# Altium schematic sheet Схема_принципиальная_общая.SchDoc
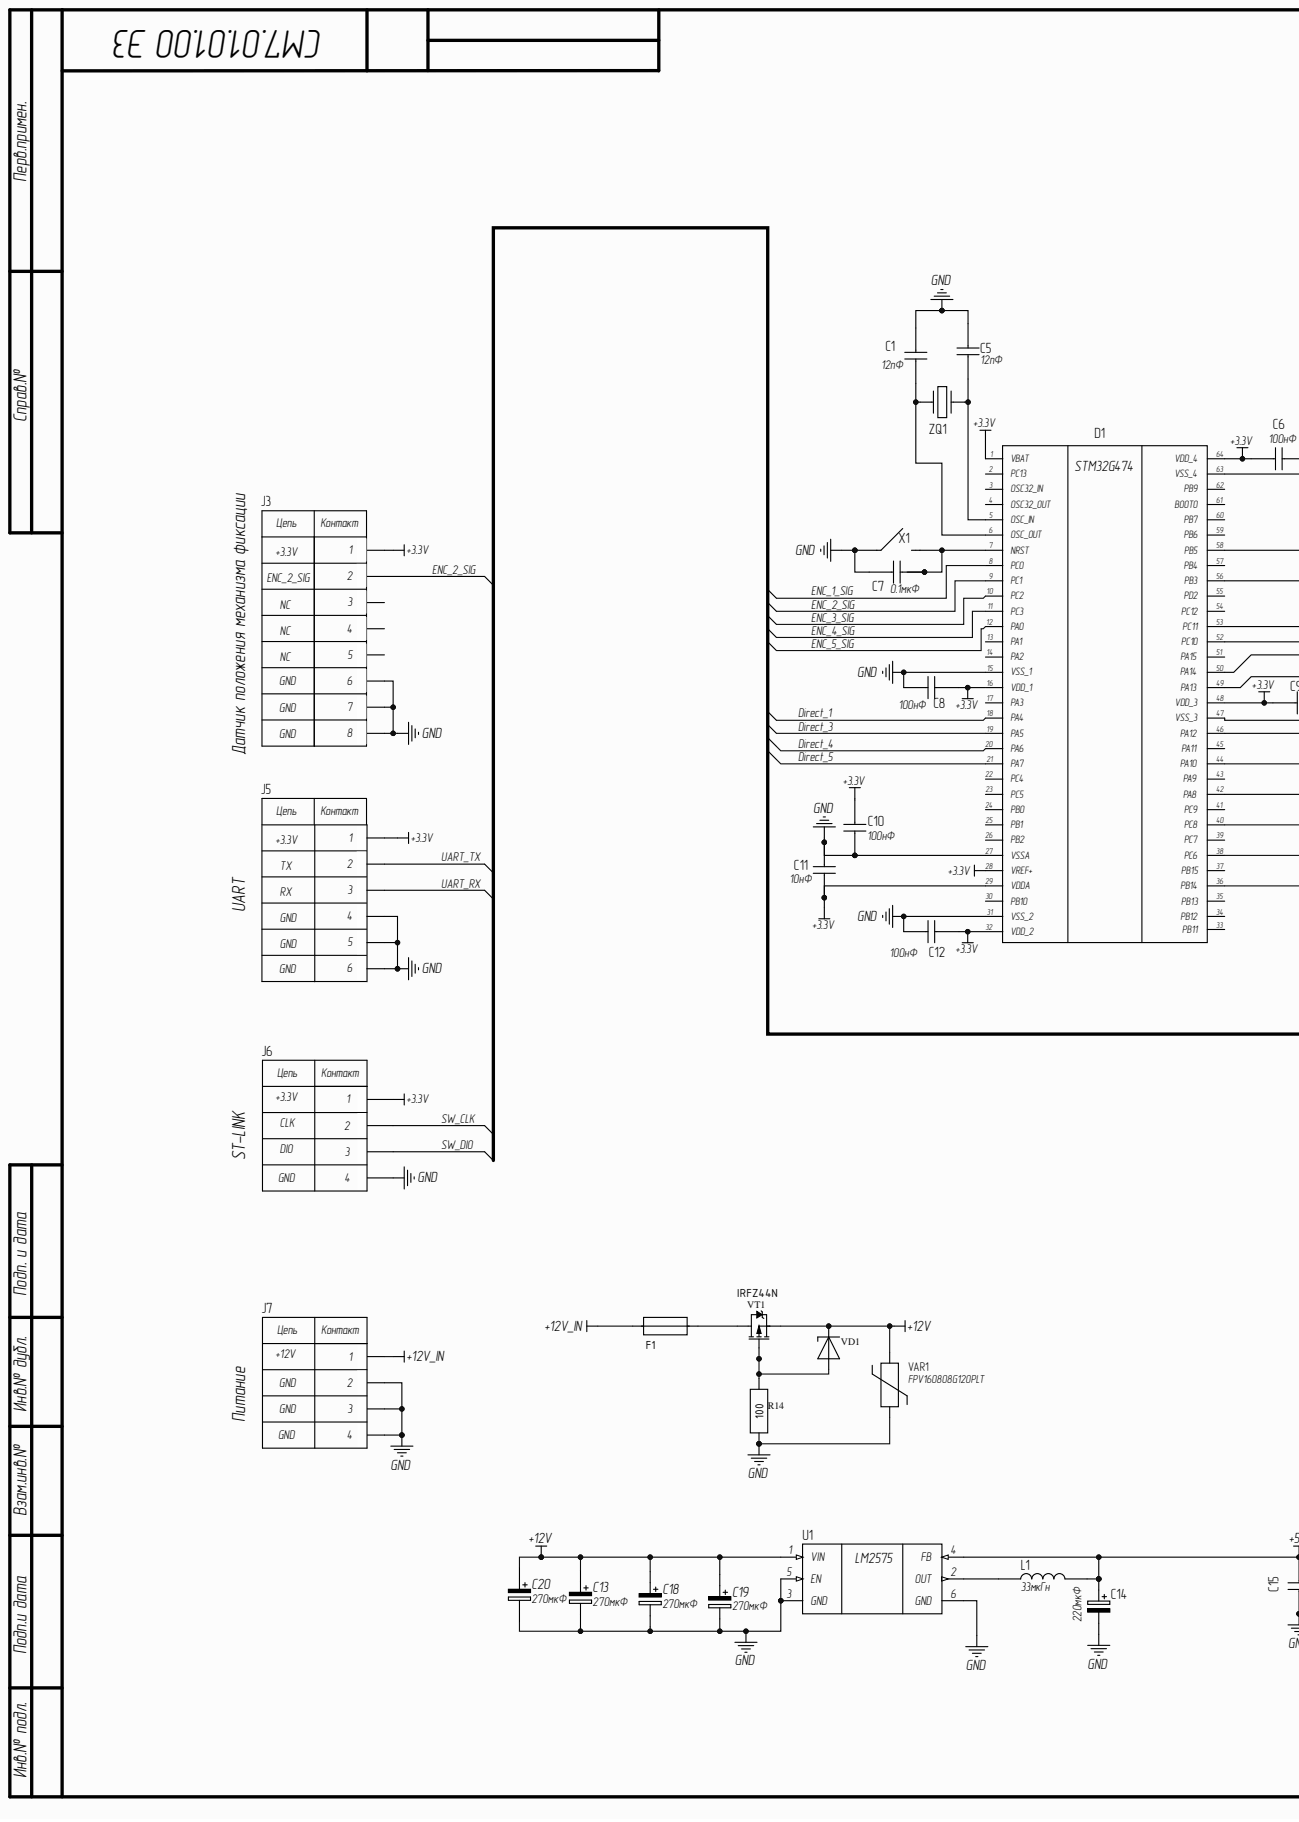
[diagram p0: Схема_принципиальная_общая.SchDoc, left]
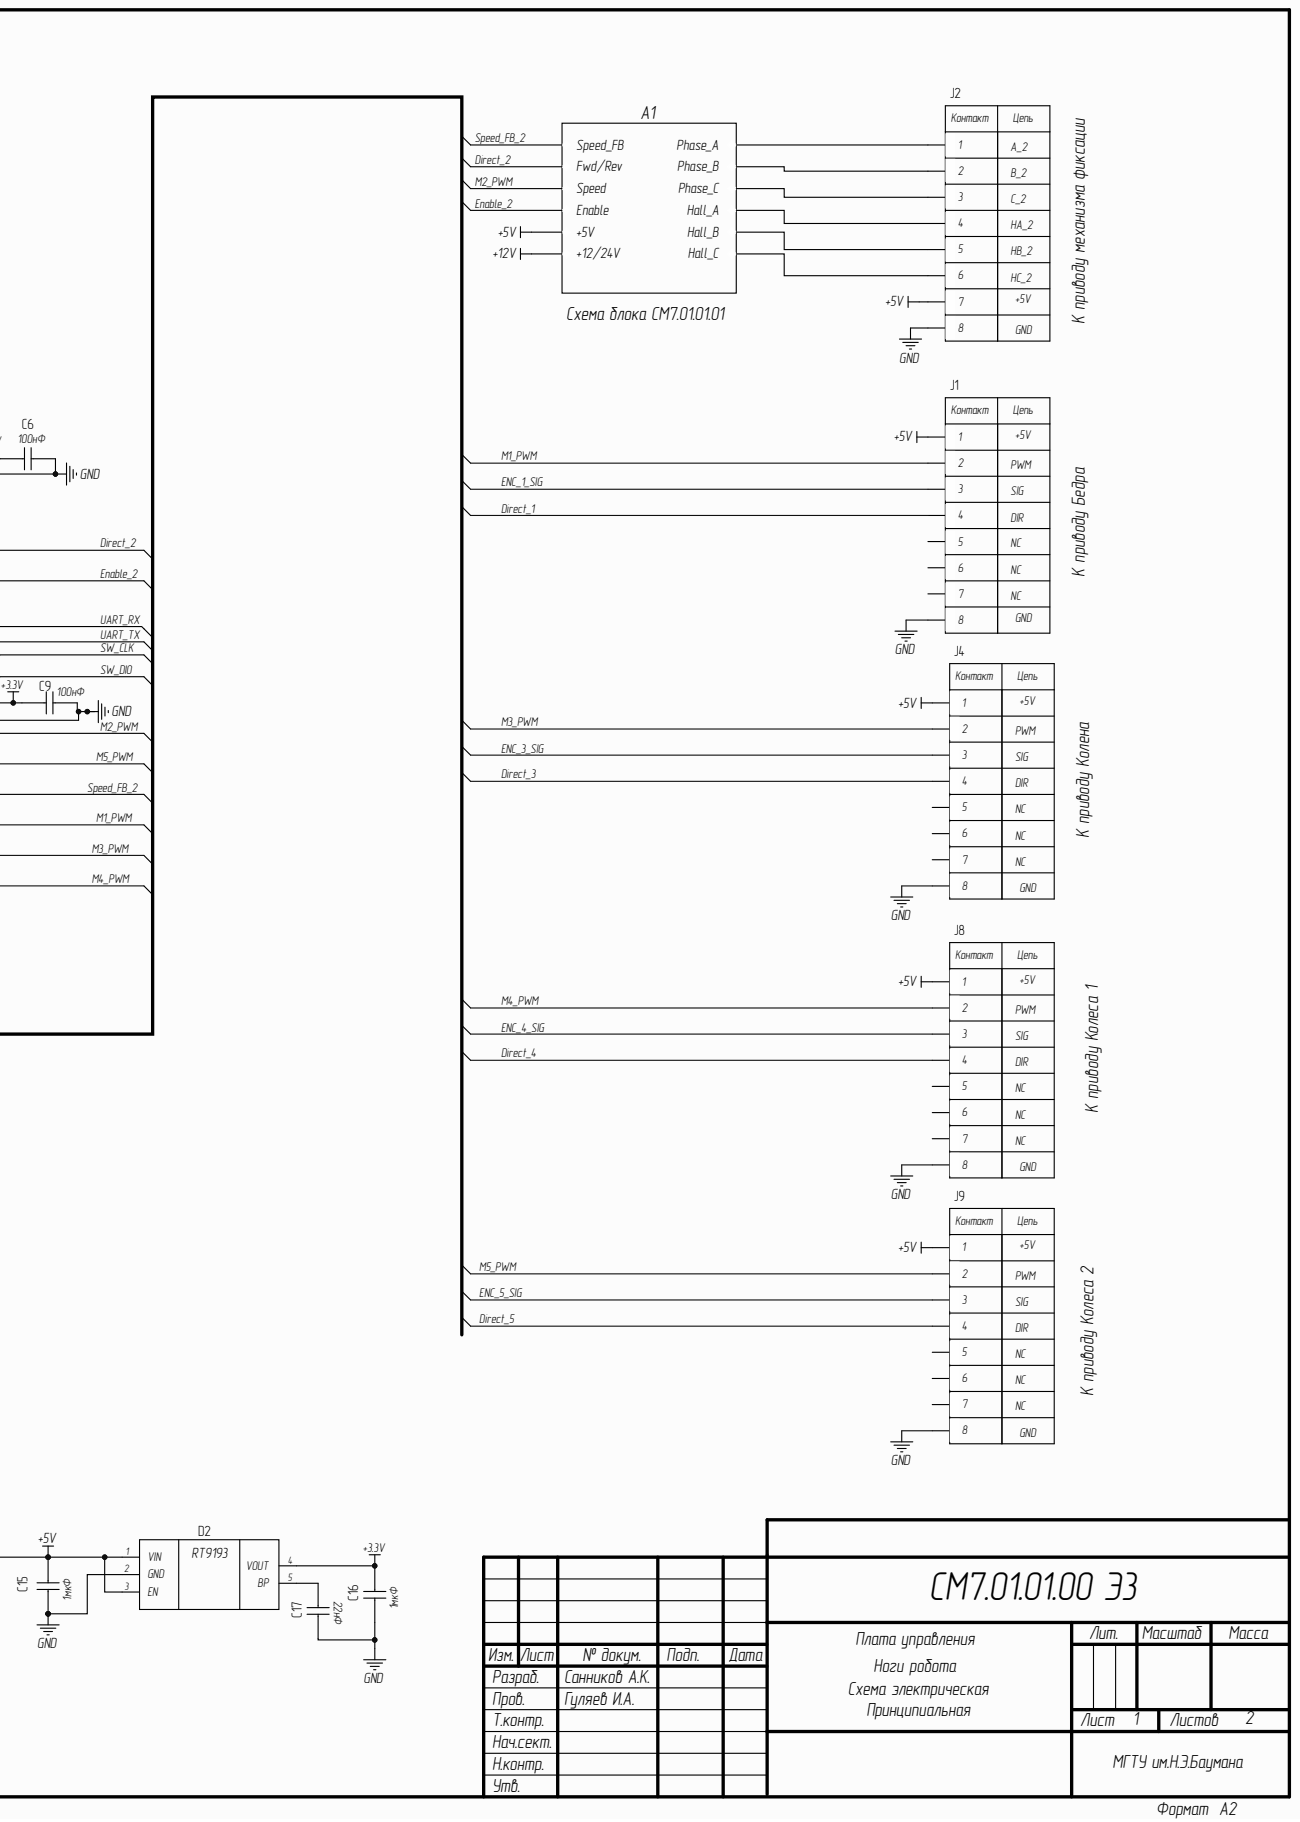
[diagram p1: Схема_принципиальная_общая.SchDoc, right]

## Parts
C1  12пФ  [CAPC1608X87N]
C5  12пФ  [CAPC1608X87N]
C6  100нФ  [CAPC1608X87N]
C7  0.1мкФ  [CAPC1608X87N]
C8  100нФ  [CAPC1608X87N]
C9  100нФ  [CAPC1608X87N]
C10  100нФ  [CAPC1608X87N]
C11  10нФ  [CAPC1608X87N]
C12  100нФ  [CAPC1608X87N]
C13  270мкФ  [16SVF270M]
C14  220мкФ  [CAPPM3216X180N]
C15  1мкФ  [CAPC1608X87N]
C16  1мкФ  [CAPC1608X87N]
C17  22нФ  [CAPC1608X87N]
C18  270мкФ  [16SVF270M]
C19  270мкФ  [16SVF270M]
C20  270мкФ  [16SVF270M]
D1  (no value)  [QFP50P1200X1200X160-64N]
D2  (no value)  [RT9193]
F1  Fuze_LB250LVF  [Fuze_LB250LVF]  (value not drawn)
J1  430450800  [MOLEX_430450800]  (value not drawn)
J2  430450800  [MOLEX_430450800]  (value not drawn)
J3  BM06B-SRSS-TB_LF__SN_  [BM06BSRSSTBLFSN]  (value not drawn)
J4  430450800  [MOLEX_430450800]  (value not drawn)
J5  BM04B-SRSS-TB_LFSN_  [BM04BSRSSTBLFSN]  (value not drawn)
J6  4-pin header  [4-pin header]  (value not drawn)
J7  XT30PW-M  [XT30PWM]  (value not drawn)
J8  430450800  [MOLEX_430450800]  (value not drawn)
J9  430450800  [MOLEX_430450800]  (value not drawn)
L1  33мкГн  [INDPM7373X450N]
R14  value 100  [RES: 0805]  (hidden comment: Resistor 0805 100 Ohm)
U1  LM2575HVSX-5.0_NOPB  [VREG_LM2575HVSX-5.0_NOPB]  (value not drawn)
VAR1  FPV160808G120PLT  [Varistor_20K391]
VD1  Zener diode, 5.1V, 500mW, 0.9V, 17Ohm, SOD-123, -55...+150°C  [DIODE: SMB]  (value not drawn)
VT1  MOSFET P-channel transistor, 20V, 2.6A, 2.9nC, 2.5W, 135mOhm, 220pF, SOT-23, -55...+150°C  [DPAK]  (value not drawn)
X1  1437566-3  [14375663]  (value not drawn)
ZQ1  NX5032GA-12.000M-LN-CD-1  [INDC5032X150N]  (value not drawn)

## Nets
+3.3V: C6.2 C8.2 C9.2 C10.2 C11.1 C12.2 C16.2 D1.1(VBAT) D1.28(VREF+) D1.29(VDDA) D1.64(VDD_4) D2.4(VOUT) J3.1 J5.1 J6.1
+5V: C14.1 C15.2 D2.1(VIN) D2.3(EN) J1.1 J2.7 J4.1 J8.1 J9.1 L1.2 U1.4(FB)
+12V: C13.1 C18.1 C19.1 C20.1 U1.1(VIN) VAR1.2 VD1.2 VT1.2
+12V_IN: F1.1 J7.1
GND: C1.2 C5.2 C6.1 C7.2 C8.1 C9.1 C10.1 C11.2 C12.1 C13.2 C14.2 C15.1 C16.1 C17.1 C18.2 C19.2 C20.2 D1.15(VSS_1) D1.27(VSSA) D1.31(VSS_2) D1.47(VSS_3) D1.63(VSS_4) D2.2(GND) J1.8 J2.8 J3.6 J3.7 J3.8 J4.8 J5.4 J5.MP1 J5.MP2 J6.4 J7.2 J7.3 J7.4 J8.8 J9.8 R14.1 U1.3(GND) U1.5(EN) U1.6(GND) VAR1.1 X1.1
Direct_1: D1.18(PA4) J1.4
Direct_2: D1.58(PB5)
Direct_3: D1.19(PA5) J4.4
Direct_4: D1.20(PA6) J8.4
Direct_5: D1.21(PA7) J9.4
Enable_2: D1.56(PB3)
ENC_1_SIG: D1.8(PC0) J1.3
ENC_2_SIG: D1.9(PC1) J3.2
ENC_3_SIG: D1.10(PC2) J4.3
ENC_4_SIG: D1.11(PC3) J8.3
ENC_5_SIG: D1.12(PA0) J9.3
M1_PWM: D1.40(PC8) J1.2
M2_PWM: D1.46(PA12)
M3_PWM: D1.38(PC6) J4.2
M4_PWM: D1.36(PB14) J8.2
M5_PWM: D1.44(PA10) J9.2
Speed_FB_2: D1.42(PA8)
SW_CLK: D1.50(PA14) J6.2
SW_DIO: D1.49(PA13) J6.3
UART_RX: D1.53(PC11) J5.3
UART_TX: D1.52(PC10) J5.2
NetC1_1: C1.1 D1.6(OSC_OUT) ZQ1.1
NetC5_1: C5.1 D1.5(OSC_IN) ZQ1.2
NetC7_1: C7.1 D1.7(NRST) X1.2
NetC17_2: C17.2 D2.5(BP)
NetD1_2: D1.2(PC13)
NetD1_3: D1.3(OSC32_IN)
NetD1_4: D1.4(OSC32_OUT)
NetD1_13: D1.13(PA1)
NetD1_14: D1.14(PA2)
NetD1_16: D1.16(VDD_1)
NetD1_17: D1.17(PA3)
NetD1_22: D1.22(PC4)
NetD1_23: D1.23(PC5)
NetD1_24: D1.24(PB0)
NetD1_25: D1.25(PB1)
NetD1_26: D1.26(PB2)
NetD1_30: D1.30(PB10)
NetD1_32: D1.32(VDD_2)
NetD1_33: D1.33(PB11)
NetD1_34: D1.34(PB12)
NetD1_35: D1.35(PB13)
NetD1_37: D1.37(PB15)
NetD1_39: D1.39(PC7)
NetD1_41: D1.41(PC9)
NetD1_43: D1.43(PA9)
NetD1_45: D1.45(PA11)
NetD1_48: D1.48(VDD_3)
NetD1_51: D1.51(PA15)
NetD1_54: D1.54(PC12)
NetD1_55: D1.55(PD2)
NetD1_57: D1.57(PB4)
NetD1_59: D1.59(PB6)
NetD1_60: D1.60(PB7)
NetD1_61: D1.61(BOOT0)
NetD1_62: D1.62(PB9)
NetF1_2: F1.2 VT1.3
NetJ1_5: J1.5
NetJ1_6: J1.6
NetJ1_7: J1.7
NetJ2_1: J2.1
NetJ2_2: J2.2
NetJ2_3: J2.3
NetJ2_4: J2.4
NetJ2_5: J2.5
NetJ2_6: J2.6
NetJ3_3: J3.3
NetJ3_4: J3.4
NetJ3_5: J3.5
NetJ4_5: J4.5
NetJ4_6: J4.6
NetJ4_7: J4.7
NetJ8_5: J8.5
NetJ8_6: J8.6
NetJ8_7: J8.7
NetJ9_5: J9.5
NetJ9_6: J9.6
NetJ9_7: J9.7
NetL1_1: L1.1 U1.2(OUT)
NetR14_2: R14.2 VD1.1 VT1.1

## Library data of the parts
C1 C5-C12 C15-C17 = C0603: C 0603; Manufacturer_Name: KEMET; Manufacturer_Part_Number: C0603C222K4REC7411; Geometry.Height: 0.87mm; Component Kind: Standard; Datasheet Link: https://www.kemet.com/specsheet/C0603C222K4REC7411; Library Name: BLDC_Library.IntLib
C13 C18-C20 = 25SVPF100M: Capacitor Polarised; Manufacturer_Name: Panasonic; Arrow Part Number: 25SVPF100M; Arrow Price/Stock: https://www.arrow.com/en/products/25svpf100m/panasonic?region=nac; Component Kind: Standard; Datasheet Link: http://industrial.panasonic.com/cdbs/www-data/pdf/AAB8000/AAB8000C177.pdf; Height: 7mm; Manufacturer_Part_Number: 25SVPF100M; Mouser Part Number: 667-25SVPF100M; Mouser Price/Stock: https://www.mouser.co.uk/ProductDetail/Panasonic/25SVPF100M?qs=OE1iw1LrrPHrTLW3S9d9vQ%3D%3D
C14 = TAJA104K050R: Capacitor tantalum TAJ 1206 100nF 50V AVX 100nF SMD Solid MnO2 Tantalum Electrolytic Capacitor, 50 V dc +/-10%, TAJ Series; Manufacturer_Name: Kyocera AVX; Geometry.Height: 1.8mm; Component Kind: Standard; Datasheet Link: http://www.farnell.com/datasheets/1680476.pdf; Library Name: BLDC_Library.IntLib; Manufacturer_Part_Number: TAJA104K050R; Mouser Part Number: N/A; Mouser Price/Stock: http://www.mouser.com/Search/ProductDetail.aspx?qs=TDs2sH6HKGut6%252bATQXwpTg%3d%3d
D1 = STM32G474RBT6: LQFP is a 64 pins, 10 x 10 mm low-profile quad flat package.; Manufacturer_Name: STMicroelectronics; Geometry.Height: 1.6mm; Arrow Part Number: STM32G474RBT6; Arrow Price/Stock: https://www.arrow.com/en/products/stm32g474rbt6/stmicroelectronics?region=nac; Component Kind: Standard; Datasheet Link: https://www.mouser.com/datasheet/2/389/stm32g474cb-1600828.pdf; Library Name: BLDC_Library.IntLib; Manufacturer_Part_Number: STM32G474RBT6; Mouser Part Number: 511-STM32G474RBT6; Mouser Price/Stock: https://www.mouser.co.uk/ProductDetail/STMicroelectronics/STM32G474RBT6?qs=uwxL4vQweFP0uAZUZXLBhQ%3D%3D
D2 = RT9193: Component Kind: Standard; Library Name: BLDC_Library.IntLib
F1 = Fuze_LB250LVF: https://www.chipdip.ru/product/lb250lvf-wayon-8030578313; Component Kind: Standard; Library Name: Smart_Boiler_Library.IntLib
J3 = BM06B-SRSS-TB_LF__SN_: Wire To Board / Wire To Wire Connector RoHS; Manufacturer_Name: JST (JAPAN SOLDERLESS TERMINALS); Manufacturer_Part_Number: BM06B-SRSS-TB(LF)(SN); Geometry.Height: 4.25mm; Arrow Part Number: BM06B-SRSS-TB(LF)(SN); Arrow Price/Stock: https://www.arrow.com/en/products/bm06b-srss-tb-lf-sn/jst-manufacturing?region=europe; Component Kind: Standard; Datasheet Link: http://www.jst-mfg.com/product/pdf/eng/eSR.pdf; Library Name: BLDC_Library.IntLib; Mouser Part Number: N/A; Mouser Price/Stock: https://www.mouser.co.uk/ProductDetail/JST-Commercial/BM06B-SRSS-TBLFSN?qs=IKkN%2F947nfAcbKIXx%2F1kIg%3D%3D
J5 = BM04B-SRSS-TB_LFSN_: JST SH, 4 Way, 1 Row, Straight PCB Header; Manufacturer_Name: JST (JAPAN SOLDERLESS TERMINALS); Manufacturer_Part_Number: BM04B-SRSS-TB(LFSN); Geometry.Height: 4.25mm; Arrow Part Number: BM04B-SRSS-TB(LFSN); Arrow Price/Stock: https://www.arrow.com/en/products/bm04b-srss-tb-lf-sn/jst-manufacturing?region=europe; Component Kind: Standard; Datasheet Link: http://uk.rs-online.com/web/p/products/5151541P; Library Name: BLDC_Library.IntLib
J7 = XT30PW-M: 30A Low Temperature Rise Fire Retardant Plug; Manufacturer_Name: Amass; Geometry.Height: 5mm; Component Kind: Standard; Datasheet Link: https://www.tme.eu/sl/Document/ce4077e36b79046da520ca73227e15de/XT30PW%20SPEC.pdf; Library Name: BLDC_Library.IntLib; Manufacturer_Part_Number: XT30PW-M
L1 = B82472G6332M000: SMD / SMT Inductors (Coils), L=3.3uH, 7.3mm x 7.3mm; Manufacturer_Name: TDK; Geometry.Height: 4.5mm; Component Kind: Standard; Datasheet Link: https://product.tdk.com/system/files/dam/doc/product/inductor/inductor/smd/data_sheet/30/db/ind_2008/b82472g6.pdf; Library Name: BLDC_Library.IntLib; Manufacturer_Part_Number: B82472G6332M000; Mouser Part Number: 871-B82472G6332M000; Mouser Price/Stock: https://www.mouser.co.uk/ProductDetail/EPCOS-TDK/B82472G6332M000?qs=x3Z3TaiycdvLmjm0xB%2FIcA%3D%3D
R14 = Resistor 0805 100 Ohm: Manufacturer: Yageo; ValueManufacturerPartNumber: Resistor film, 100, ±5%, 0805, 0.125W, -55...+125°C; Power: 0.125W; Tolerance: ±5%; TypeResistor: Resistor film; Component Kind: Standard; HelpURL: https://www.digikey.com/product-detail/en/yageo/RC0805JR-07100RL/311-100ARCT-ND/731185; Package: 0805; Temperature: -55...+125°C; Library Name: Smart_Boiler_Library.IntLib
U1 = LM2575HVSX-5.0_NOPB: Buck Switching Regulator IC Positive Fixed 5V 1 Output 1A TO-263-6, D2PAK (5 Leads + Tab), TO-263BA; MF: Microchip; MP: LM2575-5.0WU; Check_prices: https://www.snapeda.com/parts/LM2575-5.0WU/Microchip/view-part/?ref=eda; Component Kind: Standard; Library Name: BLDC_Library.IntLib; Package: TO-263-5 Microchip; SnapEDA_Link: https://www.snapeda.com/parts/LM2575-5.0WU/Microchip/view-part/?ref=snap
VAR1 = Vristor 20K391: Component Kind: Standard; Library Name: Smart_Boiler_Library.IntLib
VD1 = Zener_single: Manufacturer: Diodes Incorporated; PartNumber: MMSZ5231B-7-F; Component Kind: Standard; Current: 500mW; HelpURL: https://www.digikey.com/product-detail/en/diodes-incorporated/MMSZ5231B-7-F/MMSZ5231B-FDICT-ND/755506; Library Name: BLDC_Library_2.IntLib; Package: SOD-123; RecoveryTime: 17Ohm; Temperature: -55...+150°C; TypeDiode: Zener diode; ValueManufacturerPartNumber: Zener diode, 5.1V, 500mW, 0.9V, 17Ohm, SOD-123, -55...+150°C; Voltage: 5.1V; VoltageDrop: 0.9V
VT1 = IRFZ44N: Manufacturer: Infineon Technologies; Component Kind: Standard; CurrentDS: 2.6A; GateCharge: 2.9nC; HelpURL: https://www.digikey.com/product-detail/en/infineon-technologies/IRLML2246TRPBF/IRLML2246TRPBFCT-ND/2639576; InputCapacitor: 220pF; Library Name: BLDC_Library_2.IntLib; MaxPower: 2.5W; Package: SOT-23; PartNumber: IRFZ44N; RDS: 135mOhm; Temperature: -55...+150°C; TypeTransistor: MOSFET P-channel transistor; ValueManufacturerPartNumber: MOSFET P-channel transistor, 20V, 2.6A, 2.9nC, 2.5W, 135mOhm, 220pF, SOT-23, -55...+150°C; VoltageDS: 20V
X1 = 1437566-3: 1437566-3 - PUSHBUTTON SWITCH, SPST, 0.05A, 24V; Manufacturer_Name: TE Connectivity; Geometry.Height: 4.57mm; Arrow Part Number: 1437566-3; Arrow Price/Stock: https://www.arrow.com/en/products/1437566-3/te-connectivity?region=europe; Component Kind: Standard; Datasheet Link: https://www.te.com/commerce/DocumentDelivery/DDEController?Action=showdoc&DocId=Customer+Drawing%7F1437566-3%7FC2%7Fpdf%7FEnglish%7FENG_CD_1437566-3_C2_1437566_3_1_c.dwg.pdf%7F1437566-3; Library Name: BLDC_Library.IntLib; Manufacturer_Part_Number: 1437566-3; Mouser Part Number: N/A; Mouser Price/Stock: https://www.mouser.co.uk/ProductDetail/TE-Connectivity-Alcoswitch/1437566-3?qs=xoXDFGdkQ2nqx7TKB39mxQ%3D%3D
ZQ1 = NX5032GA-8.000M: Crystals CRYSTAL 8MHZ 8PF SMD; Manufacturer_Name: NDK; Geometry.Height: 1.5mm; Component Kind: Standard; Datasheet Link: https://componentsearchengine.com/Datasheets/1/NX5032GA-12.000M-LN-CD-1.pdf; Library Name: BLDC_Library.IntLib; Manufacturer_Part_Number: NX5032GA-12.000M-LN-CD-1; Mouser Part Number: 344-NX5032GA12MLNCD1; Mouser Price/Stock: https://www.mouser.co.uk/ProductDetail/NDK/NX5032GA-12.000M-LN-CD-1?qs=55YtniHzbhA6vGHH627DAQ%3D%3D
J1 J2 J4 J8 J9 = 430450800: Availability: Unavailable; Component Kind: Standard; Library Name: BLDC_Library.IntLib; MP: 430450800; Package: None; Price: None
J6 = 4-pin header: Component Kind: Standard; Library Name: BLDC_Library.IntLib
